# Altium schematic sheet 16_I2C_EEPROM_RTC.SchDoc
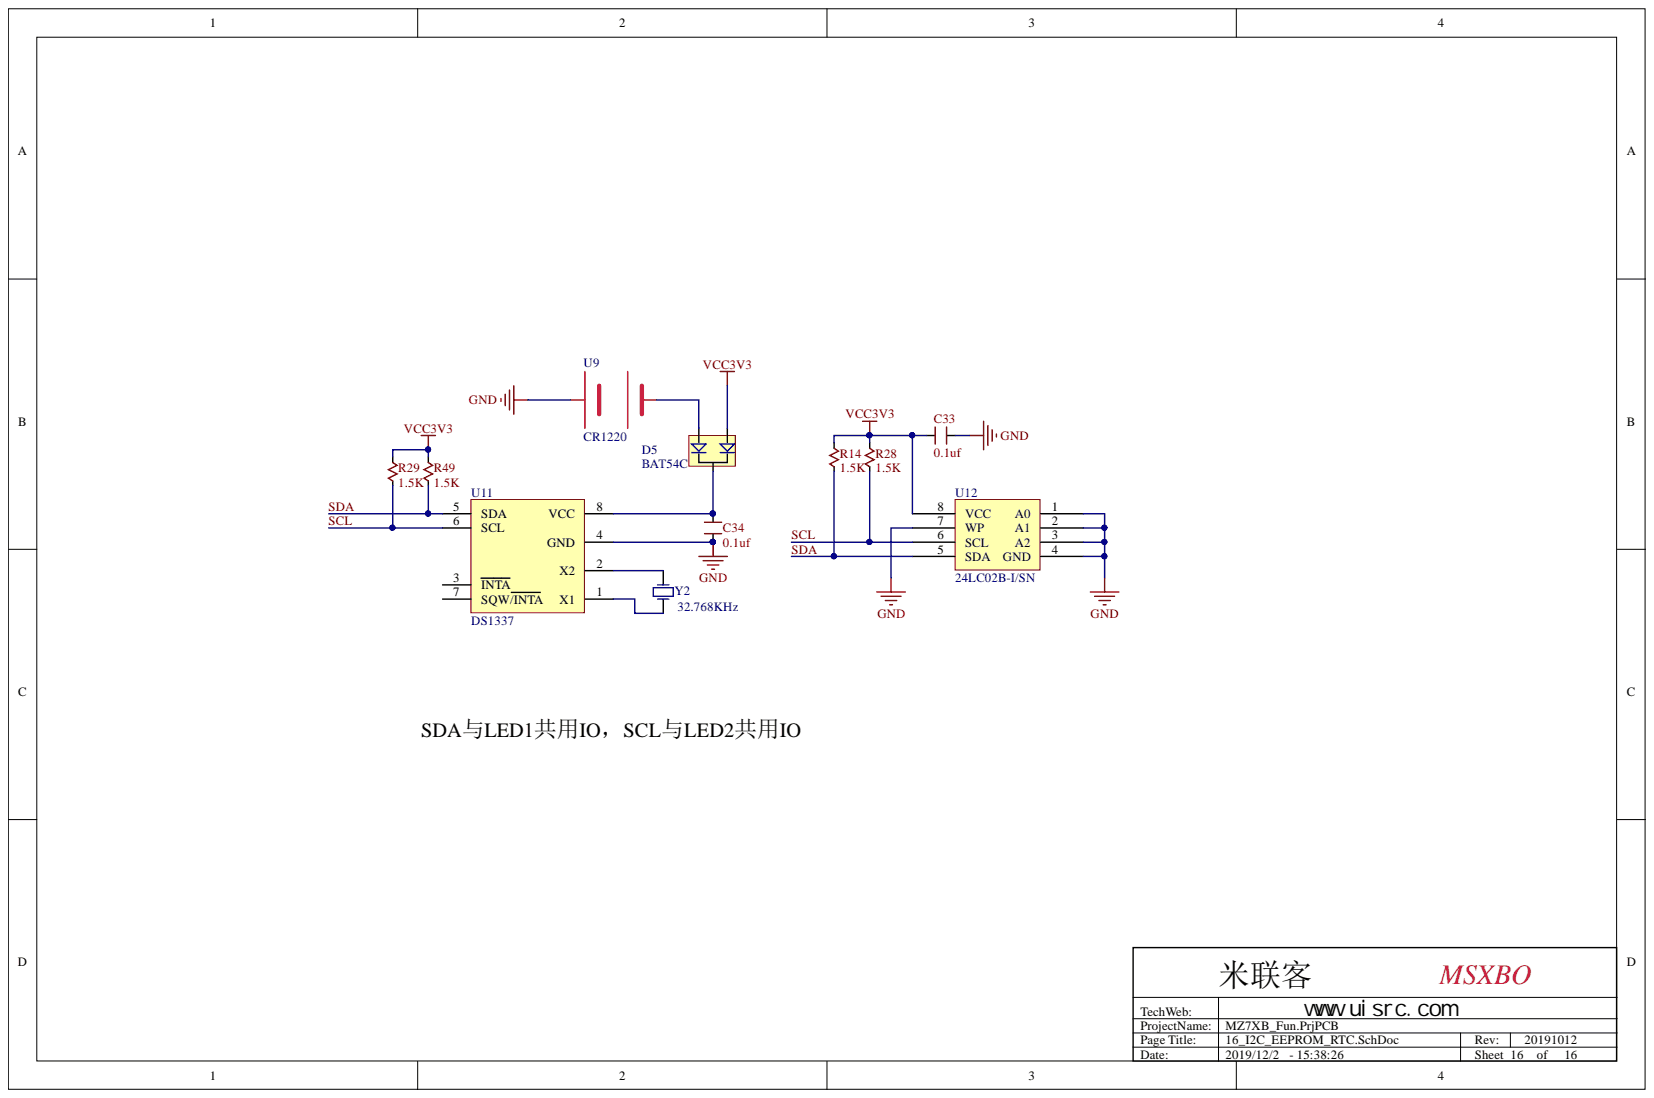
[diagram p0: 16_I2C_EEPROM_RTC.SchDoc]

## Parts
C33  0.1uf  [CAP0402]
C34  0.1uf  [CAP0402]
D5  BAT54C  [SOT-23]
R14  1.5K  [RES0402]
R28  1.5K  [RES0402]
R29  1.5K  [RES0402]
R49  1.5K  [RES0402]
U9  CR1220  [R190]
U11  DS1337  [SOP8]
U12  24LC02B-I/SN  [SOP8]
Y2  32.768KHz  [XTAL32]

## Nets
GND: C33.1 C34.2 U9.2 U11.4 U12.1 U12.2 U12.3 U12.4 U12.7
VCC3V3: C33.2 D5.1 R14.2 R28.2 R29.2 R49.2 U12.8
SCL: R28.1 R29.1 U11.6 U12.6
SDA: R14.1 R49.1 U11.5 U12.5
NetC34_1: C34.1 D5.3 U11.8
NetD5_2: D5.2 U9.1
NetU11_1: U11.1 Y2.2
NetU11_2: U11.2 Y2.1

Not connected: U11 3 7

## Library data of the parts
C33 C34 = CAPACITOR: Generic Capacitor; Component Kind: Standard; Library Name: Miz702fun_20160501.IntLib
D5 = BAT54C: 双肖特基二极管; Component Kind: Standard; Library Name: PIONEER.SCHLIB
R14 R28 R29 R49 = RESISTOR: Generic Resistor; Value: 100; Component Kind: Standard; Library Name: MiSZ7020_core.IntLib
Y2 = XTAL: 普通晶振; Component Kind: Standard; LatestRevisionDate: 17-Jul-2002; LatestRevisionNote: Re-released for DXP Platform.; Published: 8-Jun-2000; Value: 32.768KHz; Library Name: PIONEER.SCHLIB; Publisher: Altium Limited
U9 = CR1220: Component Kind: Standard; Library Name: M7Z100F.IntLib
U11 = DS1337: Component Kind: Standard; Library Name: M7Z100F.IntLib
U12 = 24LC02B-I/SN: Component Kind: Standard; Library Name: DVI-Schlib1.SchLib
